# Altium schematic sheet phy.SchDoc
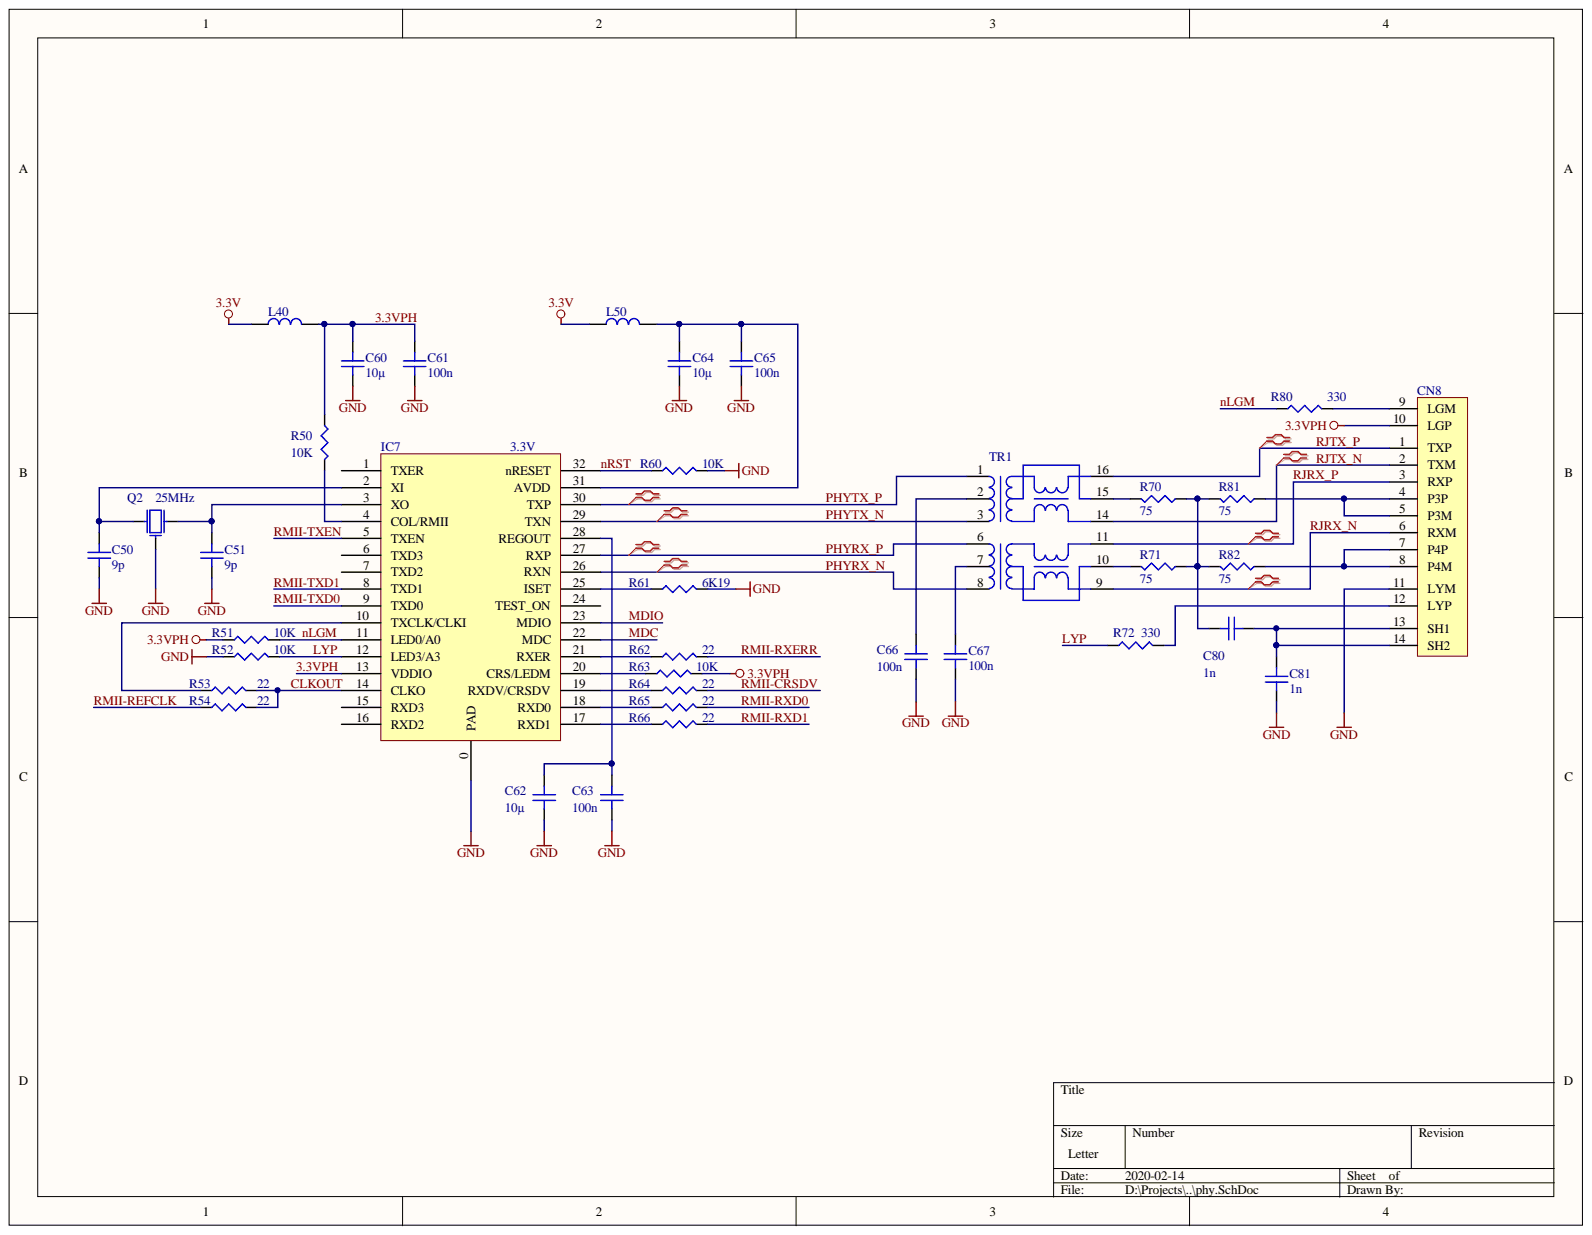
[diagram p0: phy.SchDoc]

## Parts
C50  9p  [0402_C]
C51  9p  [0402_C]
C60  10µ  [0603_C]
C61  100n  [0402_C]
C62  10µ  [0603_C]
C63  100n  [0402_C]
C64  10µ  [0603_C]
C65  100n  [0402_C]
C66  100n  [0603_C]
C67  100n  [0603_C]
C80  1n  [1206_C]
C81  1n  [1206_C]
CN8  (no value)  [RJ45-1]
IC7  3.3V  [QFN32 4x4mm]
L40  (no value)  [0402_R]
L50  (no value)  [0402_R]
Q2  25MHz  [Q-3225]
R50  10K  [0402_R]
R51  10K  [0402_R]
R52  10K  [0402_R]
R53  22  [0402_R]
R54  22  [0402_R]
R60  10K  [0402_R]
R61  6K19  [0402_R]
R62  22  [0402_R]
R63  10K  [0402_R]
R64  22  [0402_R]
R65  22  [0603_R]
R66  22  [0402_R]
R70  75  [0603_R]
R71  75  [0603_R]
R72  330  [0402_R]
R80  330  [0402_R]
R81  75  [0603_R]
R82  75  [0603_R]
TR1  (no value)  [T80E-07NL]

## Nets
3.3V: L40.2 L50.2
GND: C50.1 C51.1 C60.1 C61.1 C62.1 C63.1 C64.1 C65.1 C66.1 C67.1 C81.2 CN8.11(LYM) IC7.0(PAD) Q2.2 R52.1 R60.2 R61.2
3.3VPH: C60.2 C61.2 CN8.10(LGP) IC7.13(VDDIO) L40.1 R50.1 R51.1 R63.2
CLKOUT: IC7.14(CLKO) R53.2 R54.2
LYP: IC7.12(LED3/A3) R52.2 R72.1
MDC: IC7.22(MDC)
MDIO: IC7.23(MDIO)
NLGM: IC7.11(LED0/A0) R51.2 R80.1
NRST: IC7.32(nRESET) R60.1
PHYRX_N: IC7.26(RXN) TR1.8
PHYRX_P: IC7.27(RXP) TR1.6
PHYTX_N: IC7.29(TXN) TR1.3
PHYTX_P: IC7.30(TXP) TR1.1
RJRX_N: CN8.6(RXM) TR1.9
RJRX_P: CN8.3(RXP) TR1.11
RJTX_N: CN8.2(TXM) TR1.14
RJTX_P: CN8.1(TXP) TR1.16
RMII-CRSDV: R64.2
RMII-REFCLK: R54.1
RMII-RXD0: R65.2
RMII-RXD1: R66.2
RMII-RXERR: R62.2
RMII-TXD0: IC7.9(TXD0)
RMII-TXD1: IC7.8(TXD1)
RMII-TXEN: IC7.5(TXEN)
NetC50_2: C50.2 IC7.2(XI) Q2.1
NetC51_2: C51.2 IC7.3(XO) Q2.3
NetC62_2: C62.2 C63.2 IC7.28(REGOUT)
NetC64_2: C64.2 C65.2 IC7.31(AVDD) L50.1
NetC66_2: C66.2 TR1.2
NetC67_2: C67.2 TR1.7
NetC80_1: C80.1 R70.1 R71.1 R81.2 R82.2
NetC80_2: C80.2 C81.1 CN8.13(SH1) CN8.14(SH2)
NetCN8_4: CN8.4(P3P) CN8.5(P3M) R81.1
NetCN8_7: CN8.7(P4P) CN8.8(P4M) R82.1
NetCN8_9: CN8.9(LGM) R80.2
NetCN8_12: CN8.12(LYP) R72.2
NetIC7_4: IC7.4(COL/RMII) R50.2
NetIC7_10: IC7.10(TXCLK/CLKI) R53.1
NetIC7_17: IC7.17(RXD1) R66.1
NetIC7_18: IC7.18(RXD0) R65.1
NetIC7_19: IC7.19(RXDV/CRSDV) R64.1
NetIC7_20: IC7.20(CRS/LEDM) R63.1
NetIC7_21: IC7.21(RXER) R62.1
NetIC7_25: IC7.25(ISET) R61.1
NetR70_2: R70.2 TR1.15
NetR71_2: R71.2 TR1.10

Not connected: IC7 1(TXER) 6(TXD3) 7(TXD2) 15(RXD3) 16(RXD2) 24(TEST_ON)

## Library data of the parts
C50 C51 = Cap: CAP CER 9PF 50V COG, NPO 0402; Manufacturer: YAGEO; Part Number: CC0402CRNPO9BN9R0; Component Kind: Standard; Library Name: SchLib.SchLib
C60 C62 C64 = Cap: CAP CER 10UF 6.3V X5R 0603; Manufacturer: SAMSUNG E-M; Part Number: CL10A106MQ8NNNC; Component Kind: Standard; Library Name: SchLib.SchLib
C61 C63 C65 = Cap: CAP CER 0.1UF 16V X7R 0402; Manufacturer: YAGEO; Part Number: CC0402KRX7R7BB104; Component Kind: Standard; Library Name: SchLib.SchLib
C66 C67 = Cap: CAP CER 0.1UF 50V X7R 0603; Manufacturer: SAMSUNG E-M; Part Number: CL10B104KB8NNNC; Component Kind: Standard; Library Name: SchLib.SchLib
C80 C81 = Cap: CAP CER 1000PF 2KV X7R 1206; Manufacturer: WALSIN TECH; Part Number: 1206B102K202CT; Component Kind: Standard; Library Name: SchLib.SchLib
CN8 = RJ45-R310: MOD JACK RJ45 2LED S4.2 SHLD; Manufacturer: LIJING ELECTRONIC; Part Number: RJ310-G21-200-0R; Component Kind: Standard; Library Name: SchLib.SchLib
IC7 = IP101GR: ETH PHY (R)MII 10/100 32QFN 4x4mm; Manufacturer: IC PLUS; Component Kind: Standard; Library Name: SchLib.SchLib; Part Number: IP101GR
L40 L50 = Inductor: FERRITE BEAD 600 OHM 0402 1LN; Manufacturer: Murata; Part Number: BLM15PX601SN1D; Component Kind: Standard; Library Name: SchLib.SchLib
Q2 = Crystal4p: CRYSTAL 25MHZ 9PF SMT 3225; Manufacturer: EPSON; Part Number: X1E0000210621; Component Kind: Standard; Library Name: SchLib.SchLib
R50-R52 R60 R63 = Res: RES 10K OHM 1% 0.063W 0402; Manufacturer: YAGEO; Part Number: RC0402FR-0710KL; Component Kind: Standard; Library Name: SchLib.SchLib
R53 R54 R62 R64 R66 = Res: RES 22 OHM 1% 0.063W 0402; Manufacturer: YAGEO; Part Number: RC0402FR-0722RL; Component Kind: Standard; Library Name: SchLib.SchLib
R61 = Res: RES 6.19K OHM 1% 0.063W 0402; Manufacturer: YAGEO; Part Number: RC0402FR-076K19L; Component Kind: Standard; Library Name: SchLib.SchLib
R65 = Res: RES 22 OHM 1% 0.1W 0603; Manufacturer: WALSIN; Part Number: WR06X22R0FTL; Component Kind: Standard; Library Name: SchLib.SchLib
R70 R71 R81 R82 = Res: RES 75 OHM 1% 0.1W 0603; Manufacturer: YAGEO; Part Number: RC0603FR-0775RL; Component Kind: Standard; Library Name: SchLib.SchLib
R72 R80 = Res: RES 330 OHM 1% 0.063W 0402; Manufacturer: YAGEO; Part Number: RC0402FR-07330RL; Component Kind: Standard; Library Name: SchLib.SchLib
TR1 = TRFLAN16: TRF-LAN 350UH 100BT SMT; Manufacturer: LINK-PP; Part Number: LP1102NL; Component Kind: Standard; Library Name: SchLib.SchLib
